# Altium schematic sheet IMU.SchDoc(IMU)
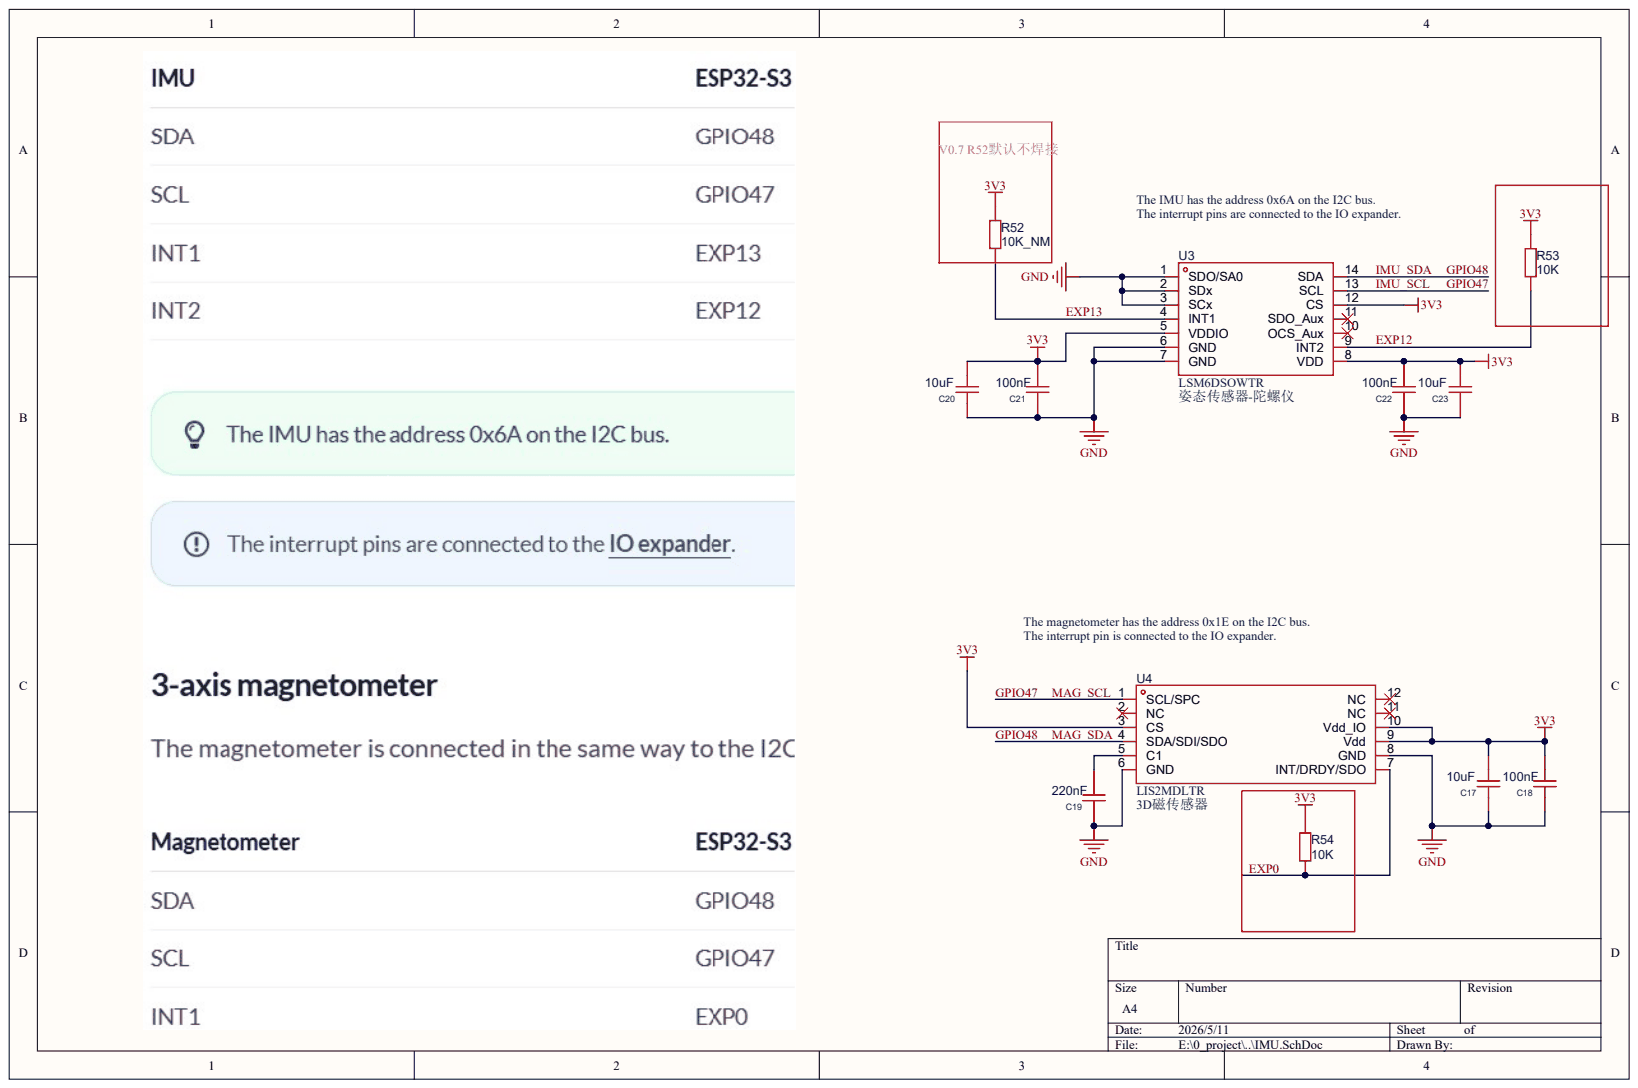
[diagram p0: IMU.SchDoc]

## Parts
C17  10uF  Capacitance: 100nF
C18  100nF
C19  220nF
C20  10uF
C21  100nF
C22  100nF
C23  10uF
R52  10K  value 10K_NM
R53  10K
R54  10K
U3  LSM6DSOWTR
U4  LIS2MDLTR

## Nets
3V3: C17.2 C18.2 C20.2 C21.2 C22.2 C23.2 R52.2 R53.2 R54.2 U3.5(VDDIO) U3.8(VDD) U3.12(CS) U4.3(CS) U4.9(Vdd) U4.10(Vdd_IO)
GND: C17.1 C18.1 C19.1 C20.1 C21.1 C22.1 C23.1 U3.1(SDO/SA0) U3.2(SDx) U3.3(SCx) U3.6(GND) U3.7(GND) U4.6(GND) U4.8(GND)
EXP0: R54.1 U4.7(INT/DRDY/SDO)
EXP12: R53.1 U3.9(INT2)
EXP13: R52.1 U3.4(INT1)
GPIO47: U3.13(SCL) U4.1(SCL/SPC)
GPIO48: U3.14(SDA) U4.4(SDA/SDI/SDO)
NetC19_2: C19.2 U4.5(C1)

Not connected: U3 10(OCS_Aux) 11(SDO_Aux); U4 2(NC) 11(NC) 12(NC)

## Library data of the parts
C17 C18 C21 C22 = (no symbol name): Symbol: GRM155R71H104KE14D; AddInBom: yes; ModelId: 36a51122f27c49738f86b3bfa98863e0; ModelName: C0402_L1.0-W0.5-H0.5; ModelTransform: 39.37,19.685,0,0,0,0,0,0,0; Voltage Rated: 50V; Temperature Coefficient: X7R; Device: GRM155R71H104KE14D; Supplier: LCSC; Manufacturer Part: GRM155R71H104KE14D; Supplier Part: C77020; Supplier Footprint: 0402; Datasheet: https://atta.szlcsc.com/upload/public/pdf/source/20180326/C77020_39BD49C951FF1AF0DB1F1FAC5AC1E401.pdf; ConvertToPcb: yes; FootprintName: R0402
  C17: Value: 10uF
  C18 C21 C22: Value: 100nF; Capacitance: 100nF
C19 C20 C23 = (no symbol name): Symbol: GRM155R71H104KE14D; Capacitance: 100nF; AddInBom: yes; ModelId: 36a51122f27c49738f86b3bfa98863e0; ModelName: C0402_L1.0-W0.5-H0.5; ModelTransform: 39.37,19.685,0,0,0,0,0,0,0; Voltage Rated: 50V; Temperature Coefficient: X7R; Device: GRM155R71H104KE14D; Supplier: LCSC; Manufacturer Part: GRM155R71H104KE14D; Supplier Part: C77020; Supplier Footprint: 0402; Datasheet: https://atta.szlcsc.com/upload/public/pdf/source/20180326/C77020_39BD49C951FF1AF0DB1F1FAC5AC1E401.pdf; ConvertToPcb: yes; FootprintName: R0402
  C19: Value: 220nF
  C20 C23: Value: 10uF
R52-R54 = (no symbol name): Device: 0402WGF4990TCE; AddInBom: yes; ModelId: 671aefced39d4c318694bba8bd8efd39; ModelName: R0402_L1.0-W0.5-H0.5; ModelTransform: 39.37,19.685,0,0,0,0,0,0.0005,0; Power(Watts): 62.5mW; Overload Voltage (Max): 50V; Symbol: 0402WGF4990TCE; SupplierPart: C4125; ManufacturerPart: 0402WGF4990TCE; Supplier Footprint: 0402; JLCPCB Part Class: Extended Part; Datasheet: https://atta.szlcsc.com/upload/public/pdf/source/20200306/C422600_1E6D84923E4A46A82E41ADD87F860B5C.pdf; Supplier: LCSC; ConvertToPcb: yes; FootprintName: R0402
  R53 R54: Value: 10K
U3 U4 = (no symbol name)
  U3: Symbol: LSM6DSOWTR
  U4: Symbol: LIS2MDLTR
